# Altium schematic sheet main.SchDoc
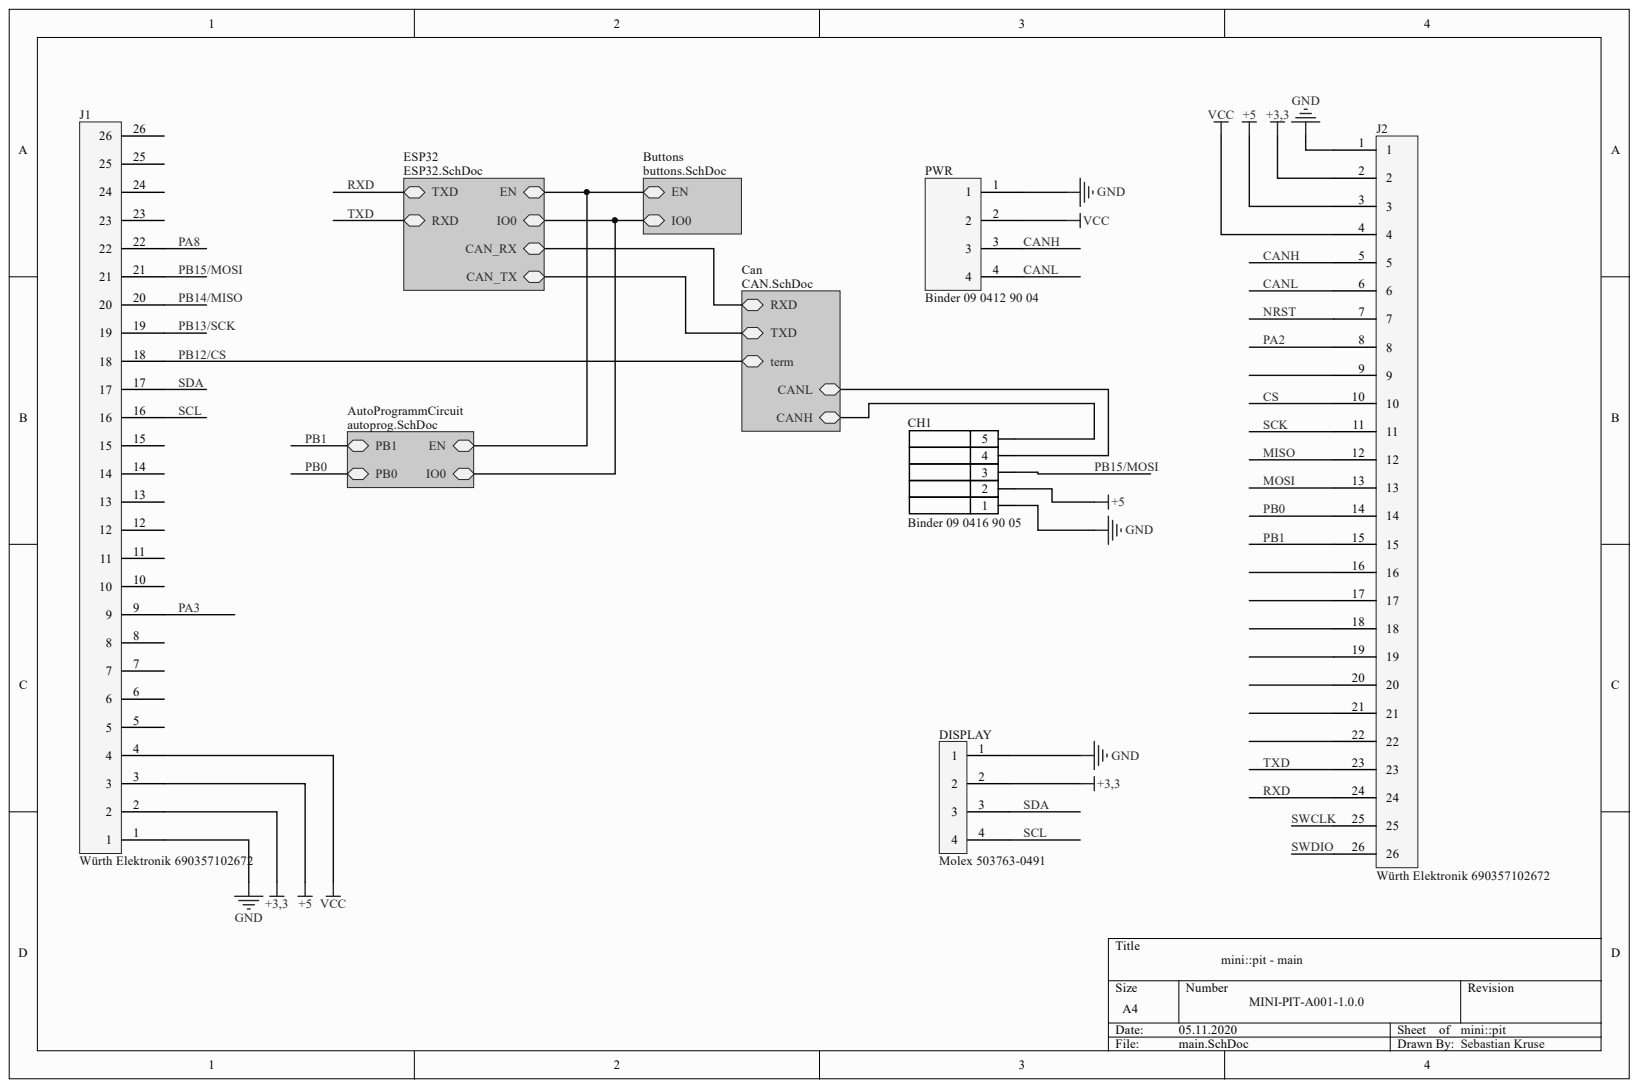
[diagram p0: main.SchDoc]

## Parts
CH1  Binder 09 0416 90 05  [PCB-xrqhdd8vohmcdf5jpup6-1]
DISPLAY  Molex 503763-0491  Manufacturer Part Number: 503763-0491  [PCB-nzup0ao0a905uvbg1qqh-1]
J1  Würth Elektronik 690357102672  Manufacturer Part Number: 690357102672  [PCB-qkngfwumds4pa5a4mwtz-1]
J2  Würth Elektronik 690357102672  [PCB-qkngfwumds4pa5a4mwtz-1]
PWR  Binder 09 0412 90 04  [PCB-cew32lc1id8viylqw7kc-1]

## Nets
+3,3: DISPLAY.2 J1.2 J2.2
+5: CH1.2 J1.3 J2.3
GND: CH1.1 DISPLAY.1 J1.1 J2.1 PWR.1
VCC: J1.4 J2.4 PWR.2
CANH: J2.5 PWR.3
CANL: J2.6 PWR.4
CS: J2.10
MISO: J2.12
MOSI: J2.13
NRST: J2.7
PA2: J2.8
PA3: J1.9
PA8: J1.22
PB0: J2.14
PB1: J2.15
PB12/CS: J1.18
PB13/SCK: J1.19
PB14/MISO: J1.20
PB15/MOSI: CH1.3 J1.21
RXD: J2.24
SCK: J2.11
SCL: DISPLAY.4 J1.16
SDA: DISPLAY.3 J1.17
SWCLK: J2.25
SWDIO: J2.26
TXD: J2.23
NetCH1_4: CH1.4
NetCH1_5: CH1.5
NetJ1_5: J1.5
NetJ1_6: J1.6
NetJ1_7: J1.7
NetJ1_8: J1.8
NetJ1_10: J1.10
NetJ1_11: J1.11
NetJ1_12: J1.12
NetJ1_13: J1.13
NetJ1_14: J1.14
NetJ1_15: J1.15
NetJ1_23: J1.23
NetJ1_24: J1.24
NetJ1_25: J1.25
NetJ1_26: J1.26
NetJ2_9: J2.9
NetJ2_16: J2.16
NetJ2_17: J2.17
NetJ2_18: J2.18
NetJ2_19: J2.19
NetJ2_20: J2.20
NetJ2_21: J2.21
NetJ2_22: J2.22
EN:
IO0:

## Library data of the parts
CH1 = CMP-b39f7ab37b186c58-1: Circular Connector, 712 Series, Receptacle, 5, Socket, Solder, Panel Mount Rohs Compliant: Yes; Component Kind: Standard; Library Name: CommunityVault; Octopart URL: https://octopart.com/09+0416+90+05-binder-2551265
DISPLAY = CMP-45bb8fbc70d79a7c-1: 1.00mm Pitch Pico-LockT Surface Mount Header, Right Angle, 1.50mm Height, Positive Side Locks, 4 Circuits; Gender: Male; Glow Wire Compliant: Non-Compliant; Number of Circuits: 4; Color: Black; Component Kind: Standard; Contact Material: Copper Alloy; Contact Plating: Gold; Halogen Free Status: Low Halogen; Library Name: FavoritesLibrary; Lifecycle Status: Active; Mounting Style: Surface Mount; Number of Contacts: 4; OctopartID: 45bb8fbc70d79a7c; Octopart URL: https://octopart.com/503763-0491-molex-51187722; Orientation: Horizontal; Packaging: Cut Tape (CT); REACH SVHC Compliance: No SVHC; RoHS: Compliant
J1 J2 = CMP-cccf062e80c51308-1: Male THT Straight Connector without Latch and with Polarization WR-MM, 26 pins; Contact Resistance: 10.0 m?; Current Rating: 1.50 A; Dielectric Withstanding Voltage: 500 V; Insulation Resistance: 1.00 ? (min); Operating Voltage: 100 V; Pin Pitch: 2.54 mm; Component Kind: Standard; Contact Material: Phosphor Bronze; Gender: Male; Library Name: FavoritesLibrary; Mounting Style: Through Hole; Number of Pins: 26; OctopartID: cccf062e80c51308; Octopart URL: https://octopart.com/690357102672-w%C3%BCrth+elektronik-65759596; Operating Temperature: -40.0 °C (min); Packaging: Tube
  J2: Manufacturer Part Number: 690357102672
PWR = CMP-937e5a2617334cb9-2: Circular Connector, 712 Series, Receptacle, 4, Socket, Solder, Panel Mount Rohs Compliant: Yes; Manufacturer Part Number: 09 0412 80 04; Contact Resistance: 2.00 m?; Current Rating: 3.00 A; Gender: Female; Number of Contacts: 4; Number of Mating Cycles: 500; Voltage Rating (AC): 125 V; Component Kind: Standard; Contact Material: Bronze; Contact Plating: Gold over Nickel, Gold; Housing Material: Nylon 6/6; Library Name: FavoritesLibrary; Mounting Style: Panel, Solder; OctopartID: 937e5a2617334cb9; Octopart URL: https://octopart.com/09+0412+80+04-binder-5415211; Operating Temperature: -40.0 °C (min); REACH SVHC Compliance: No SVHC; RoHS: Compliant
